# Altium schematic sheet CAR_FAN_RELAY_3.SchDoc(CAR_FAN_RELAY_3)
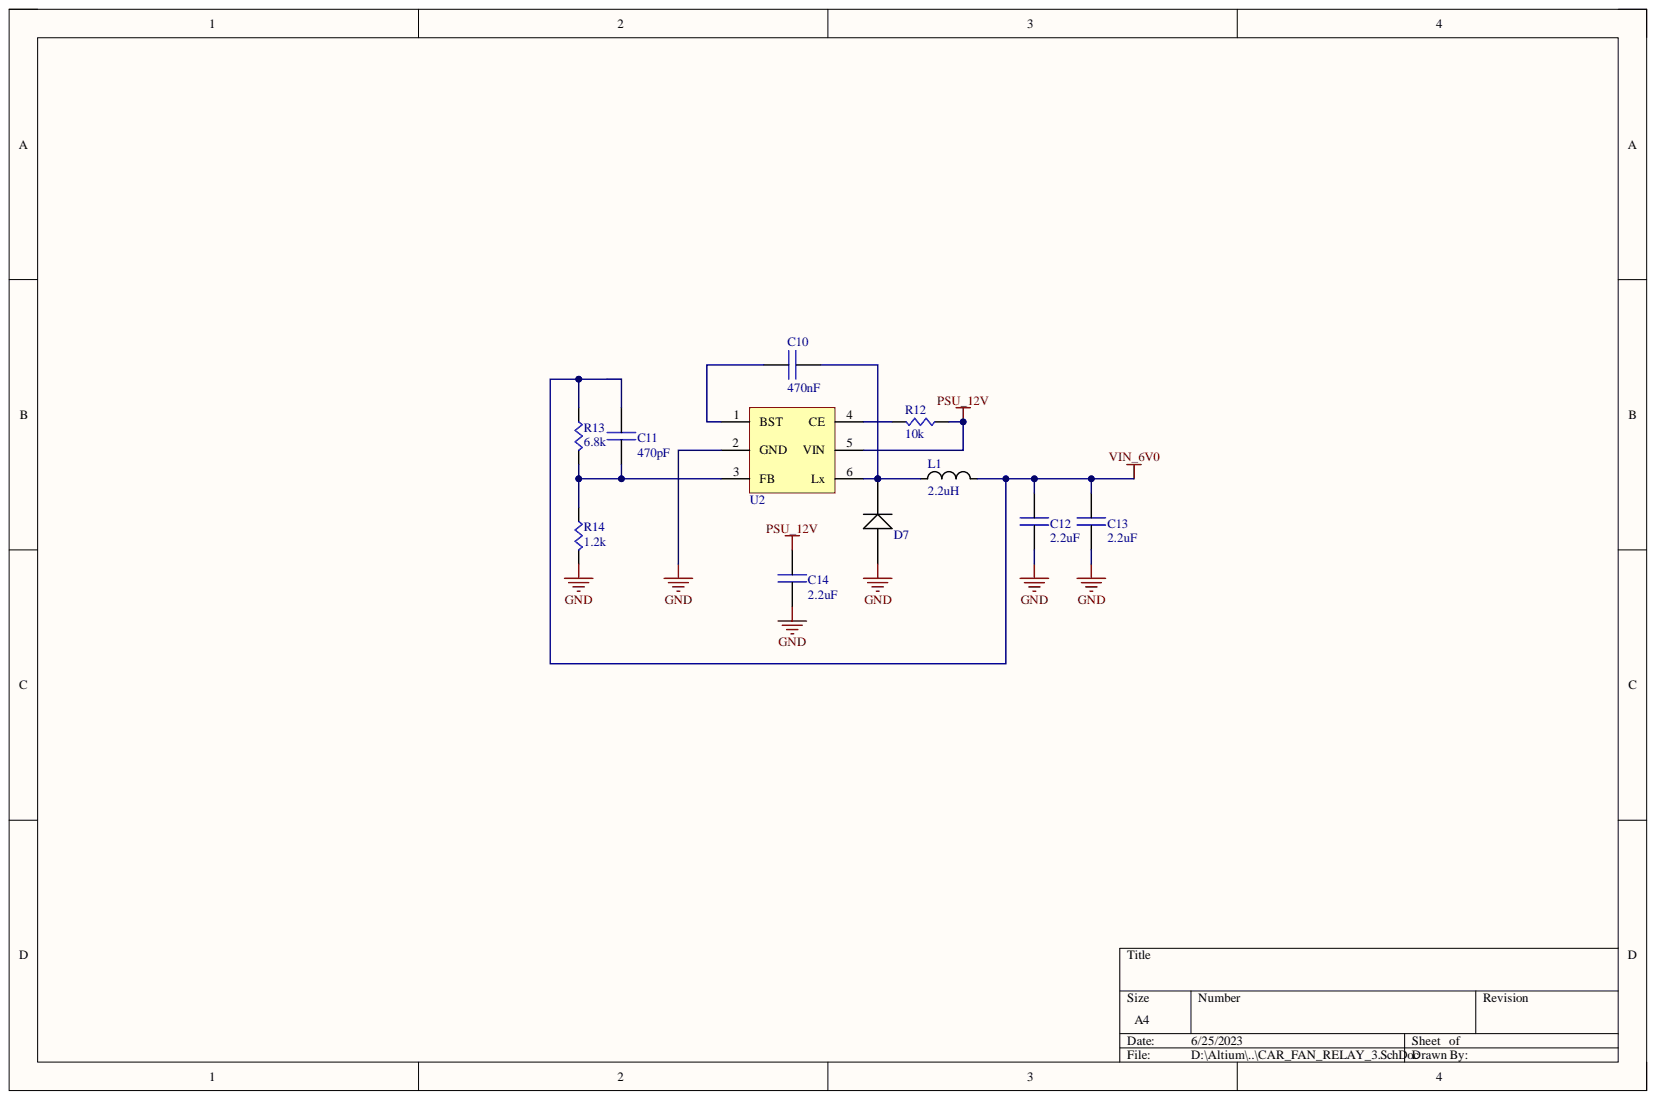
[diagram p0: CAR_FAN_RELAY_3.SchDoc]

## Parts
C10  470nF  [0603]
C11  470pF  [0603]
C12  2.2uF  [0805]
C13  2.2uF  [0805]
C14  2.2uF  [0805]
D7  SK510B-LTP  [SMB]  (value not drawn)
L1  2.2uH  [IND_0630CDMCD]
R12  10k  [0603]
R13  6.8k  [0603]
R14  1.2k  [0603]
U2  Buck  [SOT23-6]  (value not drawn)

## Nets
GND: C12.2 C13.2 C14.2 D7.A R14.1 U2.2(GND)
PSU_12V: C14.1 R12.2 U2.5(VIN)
VIN_6V0: C11.1 C12.1 C13.1 L1.2 R13.2
NetC10_1: C10.1 U2.1(BST)
NetC10_2: C10.2 D7.K L1.1 U2.6(Lx)
NetC11_2: C11.2 R13.1 R14.2 U2.3(FB)
NetR12_1: R12.1 U2.4(CE)

## Library data of the parts
C10-C14 = CAP: Unpolarised capacitor; Component Kind: Standard
  C12-C14: Library Name: CAR_FAN_RELAY.SchLib
  C10 C11: Library Name: BackscatterArraySizeSwitch.SchLib
D7 = DIODE: 5A Shottky rectifier diode; Component Kind: Standard; Library Name: CAR_FAN_RELAY.SchLib
L1 = IND_0630_2U2: 9.3A 15mR power inductor; Manufacturer: Sumida America Components Inc.; Manufacturer P/N: 0630CDMCDDS-2R2MC; Component Kind: Standard; Library Name: CAR_FAN_RELAY.SchLib; Supplier: DigiKey; Supplier P/N: 308-2480-2-ND
R12-R14 = RES: Resistor; Component Kind: Standard; Library Name: CAR_FAN_RELAY.SchLib
U2 = R1245N001B: Component Kind: Standard; Library Name: CAR_FAN_RELAY.SchLib
